# Altium schematic sheet sensor_V2.SchDoc
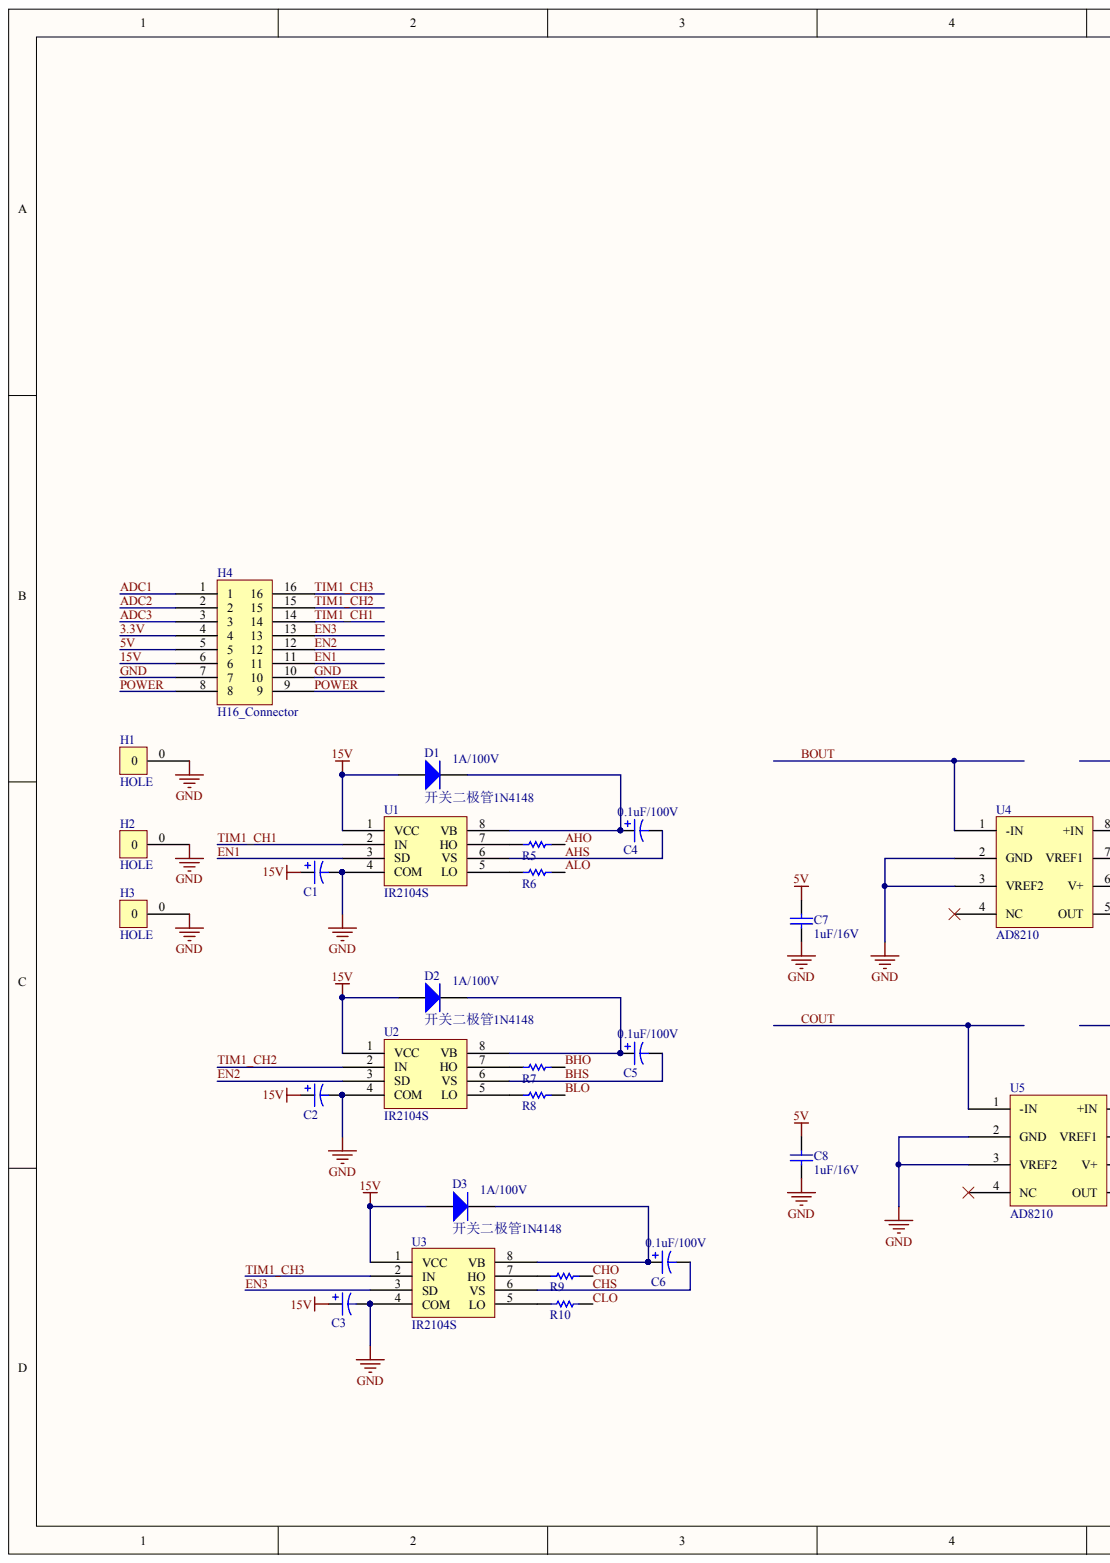
[diagram p0: sensor_V2.SchDoc, left]
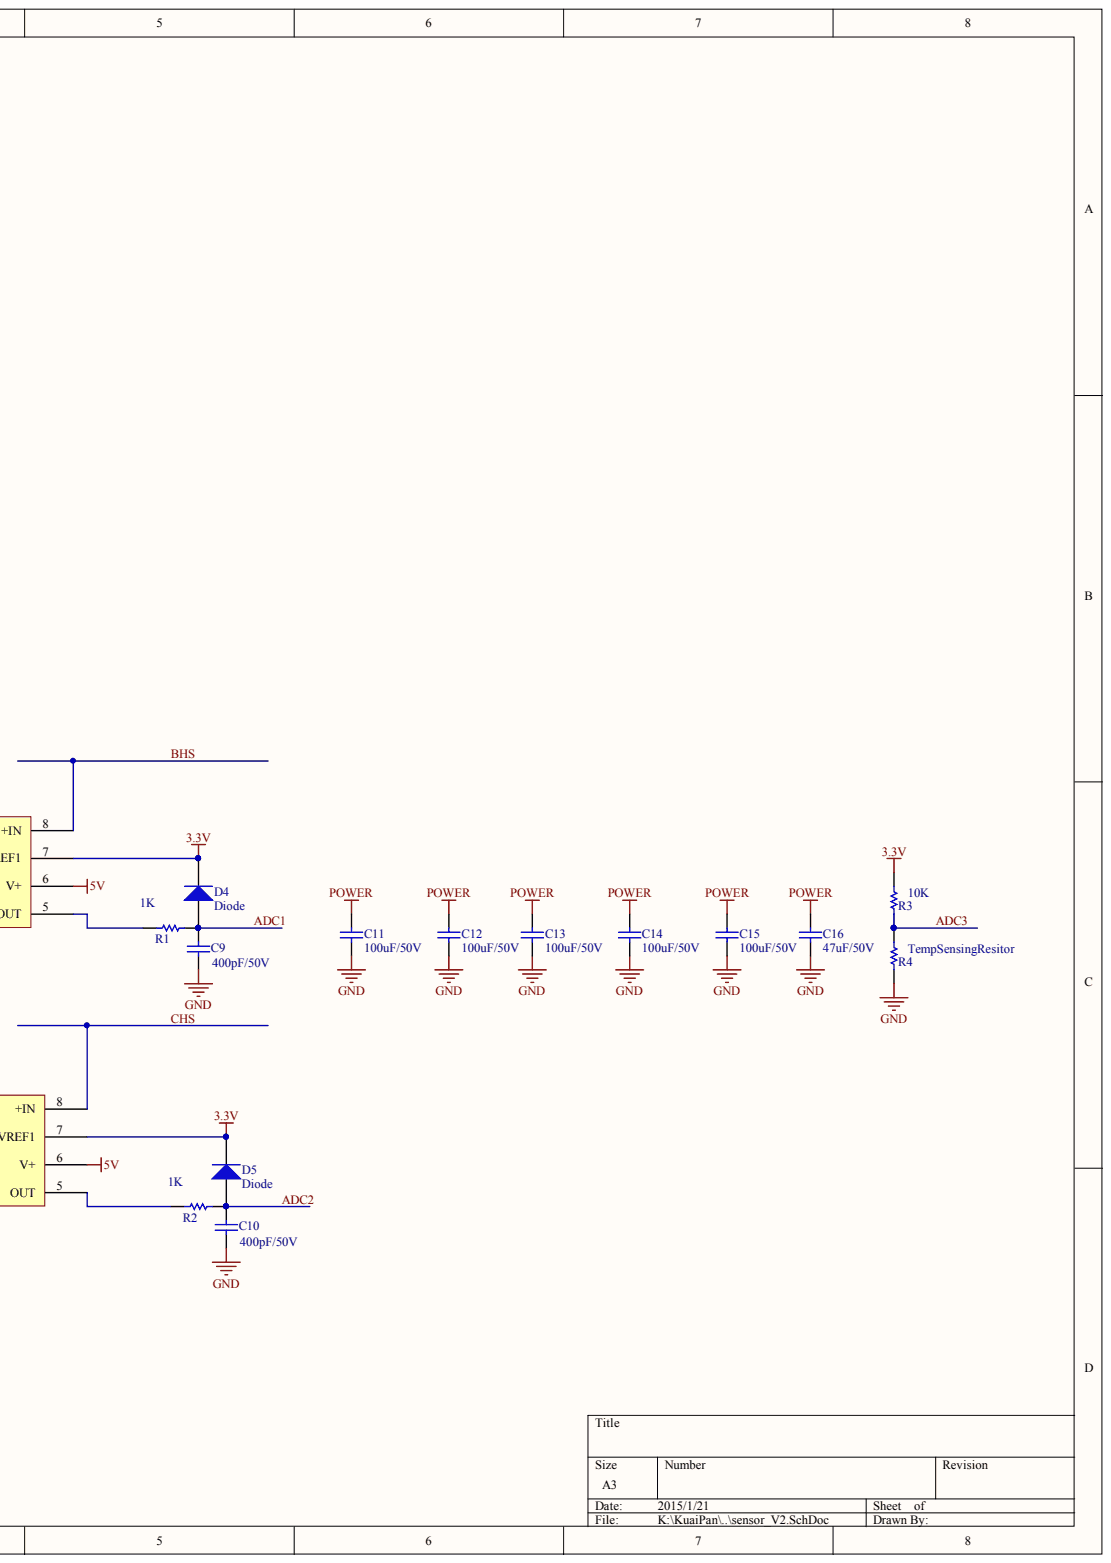
[diagram p1: sensor_V2.SchDoc, right]

## Parts
C1  Cap Pol  (value not drawn)
C2  Cap Pol  (value not drawn)
C3  Cap Pol  (value not drawn)
C4  value 0.1uF/100V  (hidden comment: Cap Pol)
C5  value 0.1uF/100V  (hidden comment: Cap Pol)
C6  value 0.1uF/100V  (hidden comment: Cap Pol)
C7  value 1uF/16V  (hidden comment: Cap)
C8  value 1uF/16V  (hidden comment: Cap)
C9  value 400pF/50V  (hidden comment: Cap)
C10  value 400pF/50V  (hidden comment: Cap)
C11  value 100uF/50V  (hidden comment: Cap)
C12  value 100uF/50V  (hidden comment: Cap)
C13  value 100uF/50V  (hidden comment: Cap)
C14  value 100uF/50V  (hidden comment: Cap)
C15  value 100uF/50V  (hidden comment: Cap)
C16  value 47uF/50V  (hidden comment: Cap)
D1  开关二极管1N4148  value 1A/100V
D2  开关二极管1N4148  value 1A/100V
D3  开关二极管1N4148  value 1A/100V
D4  Diode
D5  Diode
H1  HOLE
H2  HOLE
H3  HOLE
H4  H16_Connector
R1  value 1K  (hidden comment: RES)
R2  value 1K  (hidden comment: RES)
R3  value 10K  (hidden comment: RES)
R4  value TempSensingResitor  (hidden comment: RES)
R5  RES  (value not drawn)
R6  RES  (value not drawn)
R7  RES  (value not drawn)
R8  RES  (value not drawn)
R9  RES  (value not drawn)
R10  RES  (value not drawn)
U1  IR2104S
U2  IR2104S
U3  IR2104S
U4  AD8210
U5  AD8210

## Nets
3.3V: D4.2 D5.2 H4.4 R3.1 U4.7(VREF1) U5.7(VREF1)
5V: C7.1 C8.1 H4.5 U4.6(V+) U5.6(V+)
15V: C1.1 C2.1 C3.1 D1.1 D2.1 D3.1 H4.6 U1.1(VCC) U2.1(VCC) U3.1(VCC)
ADC1: C9.2 D4.1 H4.1 R1.2
ADC2: C10.2 D5.1 H4.2 R2.2
ADC3: H4.3 R3.2 R4.2
AHO: R5.2
AHS: C4.2 U1.6(VS)
ALO: R6.2
BHO: R7.2
BHS: C5.2 U2.6(VS) U4.8(+IN)
BLO: R8.2
BOUT: U4.1(-IN)
CHO: R9.2
CHS: C6.2 U3.6(VS) U5.8(+IN)
CLO: R10.2
COUT: U5.1(-IN)
EN1: H4.11 U1.3(SD)
EN2: H4.12 U2.3(SD)
EN3: H4.13 U3.3(SD)
GND: C1.2 C2.2 C3.2 C7.2 C8.2 C9.1 C10.1 C11.2 C12.2 C13.2 C14.2 C15.2 C16.2 H1.0 H2.0 H3.0 H4.7 H4.10 R4.1 U1.4(COM) U2.4(COM) U3.4(COM) U4.2(GND) U4.3(VREF2) U5.2(GND) U5.3(VREF2)
POWER: C11.1 C12.1 C13.1 C14.1 C15.1 C16.1 H4.8 H4.9
TIM1_CH1: H4.14 U1.2(IN)
TIM1_CH2: H4.15 U2.2(IN)
TIM1_CH3: H4.16 U3.2(IN)
NetC4_1: C4.1 D1.2 U1.8(VB)
NetC5_1: C5.1 D2.2 U2.8(VB)
NetC6_1: C6.1 D3.2 U3.8(VB)
NetR1_1: R1.1 U4.5(OUT)
NetR2_1: R2.1 U5.5(OUT)
NetR5_1: R5.1 U1.7(HO)
NetR6_1: R6.1 U1.5(LO)
NetR7_1: R7.1 U2.7(HO)
NetR8_1: R8.1 U2.5(LO)
NetR9_1: R9.1 U3.7(HO)
NetR10_1: R10.1 U3.5(LO)

Not connected: U4 4(NC); U5 4(NC)

## Library data of the parts
C1-C3 = (no symbol name): Value: 10uF/16V
D4 D5 = (no symbol name): Value: 快速恢复二极管
H1-H3 = (no symbol name): Value: D2mm
R5-R10 = (no symbol name): Value: 2R7
C4-C16 D1-D3 H4 R1-R4 U1-U5 = (no symbol name)
  H4: Value: H16_Connector
  U1-U3: Value: IR2104S
  U4 U5: Value: AD8210
